# Altium schematic sheet ANNA-B5 Dice_Top.SchDoc("ANNA-B5 Dice_Top")
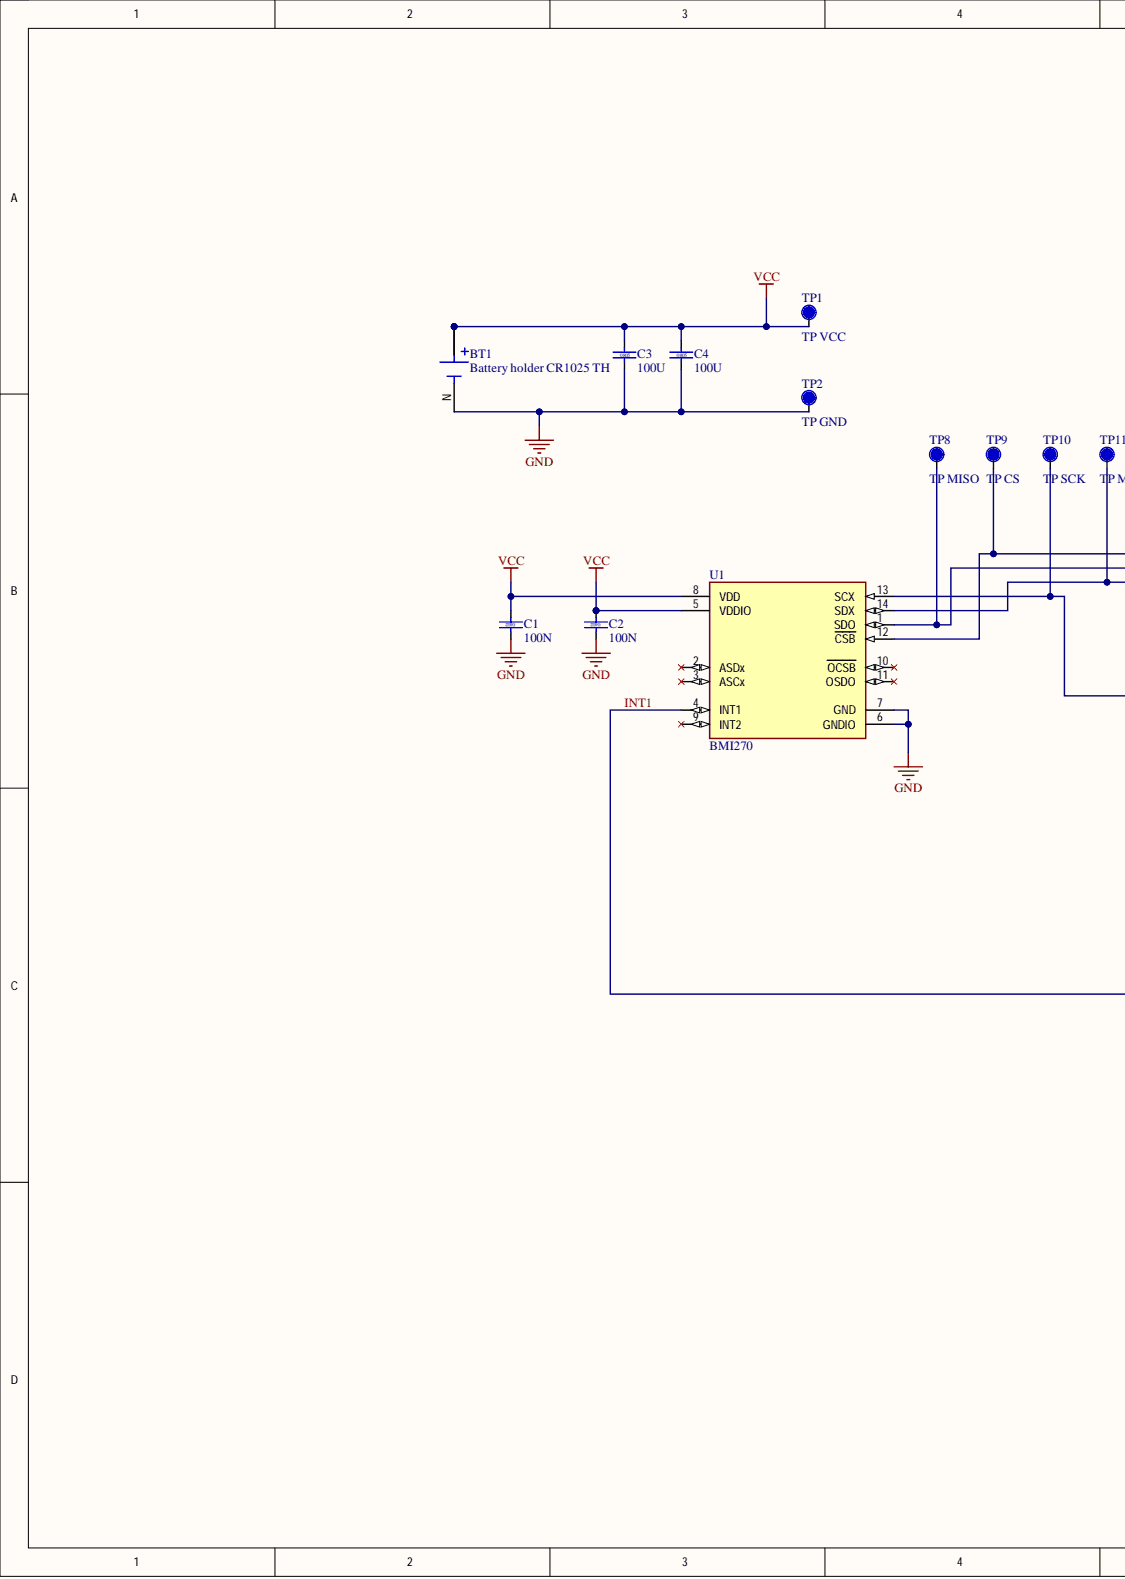
[diagram p0: ANNA-B5 Dice_Top.SchDoc, left]
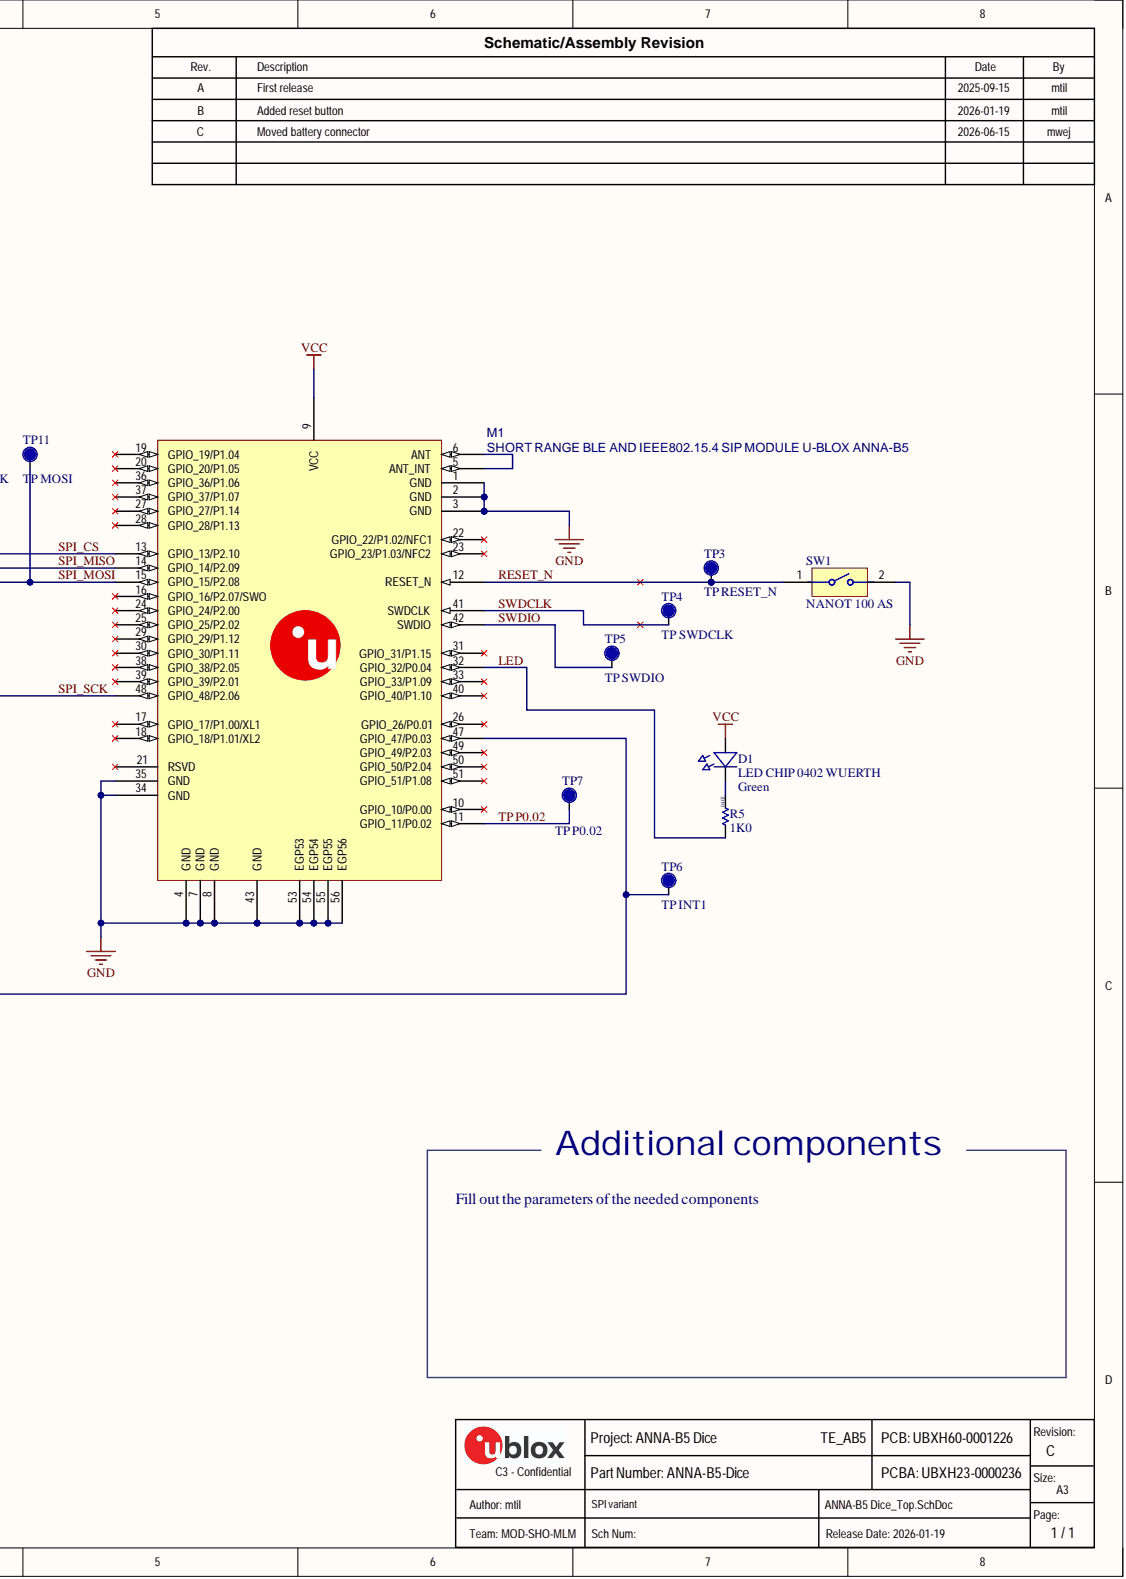
[diagram p1: ANNA-B5 Dice_Top.SchDoc, right]

## Parts
BT1  Battery holder CR1025 TH  value CR1025  [BAT_3031]
C1  value 100N  Case/Package: 0402  [Cap_0402_1100Lx600Wx600H_600x600Pad_350G_FLX]  (hidden comment: CAP CER X7R 0402 100N 10% 16V)
C2  value 100N  Case/Package: 0402  [Cap_0402_1100Lx600Wx600H_600x600Pad_350G_FLX]  (hidden comment: CAP CER X7R 0402 100N 10% 16V)
C3  value 100U  Case/Package: 0805  [CAP_0805_2200Lx1450Wx1400H_700x1500Pad_1100G_FLX]  (hidden comment: CAP CER X5R 0805 100U 20% 6.3V)
C4  value 100U  Case/Package: 0805  [CAP_0805_2200Lx1450Wx1400H_700x1500Pad_1100G_FLX]  (hidden comment: CAP CER X5R 0805 100U 20% 6.3V)
D1  LED CHIP 0402 WUERTH  Case/Package: 0402  Color: Green  [LED_0402]
M1  SHORT RANGE BLE AND IEEE802.15.4 SIP MODULE U-BLOX ANNA-B5  [ANNA-B5]
R5  value 1K0  Case/Package: 0402  [RES_0402_1100Lx600Wx400H_500x600Pad_400G_FLX]  (hidden comment: RES THICK FILM CHIP 0402 1K0 5% 100mW)
SW1  NANOT 100 AS  [NANOT160AS]
TP1  TP VCC  [TP10R_NO_SILK]
TP2  TP GND  [TP10R_NO_SILK]
TP3  TP RESET_N  [TP10R_NO_SILK]
TP4  TP SWDCLK  [TP10R_NO_SILK]
TP5  TP SWDIO  [TP10R_NO_SILK]
TP6  TP INT1  [TP10R_NO_SILK]
TP7  TP P0.02  [STP_600]
TP8  TP MISO  [STP_600]
TP9  TP CS  [STP_600]
TP10  TP SCK  [STP_600]
TP11  TP MOSI  [STP_600]
U1  BMI270  [LGA0.5P3x2.5_14Pin]

## Nets
GND: BT1.N C1.1 C2.1 C3.2 C4.2 M1.1(GND) M1.2(GND) M1.3(GND) M1.4(GND) M1.7(GND) M1.8(GND) M1.34(GND) M1.35(GND) M1.43(GND) M1.53(EGP53) M1.54(EGP54) M1.55(EGP55) M1.56(EGP56) SW1.2 TP2.1 U1.6(GNDIO) U1.7(GND)
VCC: BT1.P1 BT1.P2 C1.2 C2.2 C3.1 C4.1 D1.2 M1.9(VCC) TP1.1 U1.5(VDDIO) U1.8(VDD)
INT1: M1.47(GPIO_47/P0.03) TP6.1 U1.4(INT1)
LED: M1.32(GPIO_32/P0.04) R5.1
RESET_N: M1.12(RESET_N) SW1.1 TP3.1
SPI_CS: M1.13(GPIO_13/P2.10) TP9.1 U1.12(CSB)
SPI_MISO: M1.14(GPIO_14/P2.09) TP8.1 U1.1(SDO)
SPI_MOSI: M1.15(GPIO_15/P2.08) TP11.1 U1.14(SDX)
SPI_SCK: M1.48(GPIO_48/P2.06) TP10.1 U1.13(SCX)
SWDCLK: M1.41(SWDCLK) TP4.1
SWDIO: M1.42(SWDIO) TP5.1
TP P0.02: M1.11(GPIO_11/P0.02) TP7.1
NetD1_1: D1.1 R5.2
NetM1_5: M1.5(ANT_INT) M1.6(ANT)

Not connected: M1 10(GPIO_10/P0.00) 16(GPIO_16/P2.07/SWO) 17(GPIO_17/P1.00/XL1) 18(GPIO_18/P1.01/XL2) 19(GPIO_19/P1.04) 20(GPIO_20/P1.05) 21(RSVD) 22(GPIO_22/P1.02/NFC1) 23(GPIO_23/P1.03/NFC2) 24(GPIO_24/P2.00) 25(GPIO_25/P2.02) 26(GPIO_26/P0.01) 27(GPIO_27/P1.14) 28(GPIO_28/P1.13) 29(GPIO_29/P1.12) 30(GPIO_30/P1.11) 31(GPIO_31/P1.15) 33(GPIO_33/P1.09) 36(GPIO_36/P1.06) 37(GPIO_37/P1.07) 38(GPIO_38/P2.05) 39(GPIO_39/P2.01) 40(GPIO_40/P1.10) 49(GPIO_49/P2.03) 50(GPIO_50/P2.04) 51(GPIO_51/P1.08); U1 2(ASDx) 3(ASCx) 9(INT2) 10(OCSB) 11(OSDO)

## Library data of the parts
BT1 = UBXCMP-BAT-00022-8: Battery Clip; THM; 9-10mm Cell; PhosBronze/Tin-Nickel; Critical Part: No; Part Number: UBXH03-0000012; Component Mounting Type: Through Hole; Environmental Grade: RoHS; PLM Lifecycle Phase: Limited Production; PLM Revision: A; Use in new designs: Yes; Automotive: No; Check_prices: https://www.snapeda.com/parts/3031/Keystone/view-part/?ref=eda; Component Kind: Standard; Component Type: Standard; Design Item ID: 3031; Diameter: 10 mm; Height: 3 mm; Package: None; Part Status: Preferred; Pins: 3; Value: CR1025; Library Name: u-blox
C1 C2 = UBXCMP-CAP-00170-4: Critical Part: No; Part Number: UBXH01-0000233; Component Mounting Type: SMT; Environmental Grade: RoHS; JEDEC Type: S0402_C; Owner: Philippe Largier (null); Pack Type: DEFAULT; PLM Lifecycle Phase: Full Production; PLM Revision: A; Use in new designs: Yes; Automotive: No; Component Kind: Standard; Description: CAP CER X7R 0402 100N 10% 16V; Max Operating Temperature: 125°C; Maximum Voltage: 16V; Min Operating Temperature: -55°C; Part: CAP; Part Status: Preferred; Temperature Coefficient: X7R; Tolerance: 10%; Volume Use: Yes; Library Name: u-blox
C3 C4 = UBXCMP-CAP-00261-4: Critical Part: No; Part Number: UBXH01-0000327; Component Mounting Type: SMT; Environmental Grade: RoHS; JEDEC Type: S0805_C; Owner: Martin Grasse (null); Pack Type: DEFAULT; PLM Lifecycle Phase: Limited Production; PLM Revision: A; Use in new designs: Yes; Automotive: No; Component Kind: Standard; Description: CAP CER X5R 0805 100U 20% 6.3V; Max Operating Temperature: 85°C; Maximum Voltage: 6.3V; Min Operating Temperature: -55°C; Part: CAP; Part Status: Preferred; Temperature Coefficient: X5R; Tolerance: 20%; Volume Use: No; Library Name: u-blox
D1 = UBXCMP-LED-00019-3: Critical Part: No; Part: DIO_LED; Part Number: UBXH11-0000042; Component Mounting Type: SMT; Environmental Grade: RoHS; JEDEC Type: SWURTH_150040VS73; Number of Circuits: 1; Owner: George Metaxas (null); Pack Type: DEFAULT; PLM Lifecycle Phase: Full Production; PLM Revision: A; Use in new designs: Yes; Automotive: No; Component Kind: Standard; Description: LED CHIP 0402 WUERTH; Max Operating Temperature: 85°C; Min Operating Temperature: -40°C; Part Status: Preferred; Volume Use: Yes; Library Name: u-blox
M1 = UBXCMP-MOD-00552-4: Critical Part: No; Part: ublox_anna_b5; Part Number: UBXH29-0000036; Component Mounting Type: SMT; Core Architecture: Cortex®-M33; Environmental Grade: RoHS; Flash Size: 1524 KB; Internal Antenna: Yes; Owner: Yaqin Chen (null); Pack Type: Default; PLM Lifecycle Phase: Unreleased; PLM Revision: 1; RAM Size: 256 KB; RF Frequency: 2.4GHz; RF Protocol: BLE 6.0 and IEEE802.15.4-2020; Automotive: No; Component Kind: Standard; Description: SHORT RANGE BLE AND IEEE802.15.4 SIP MODULE U-BLOX ANNA-B5; Library Name: u-blox; Max Operating Temperature: 85°C; Min Operating Temperature: -40°C; Part Status: Preferred; Use in new designs: Yes; Volume Use: Yes
R5 = UBXCMP-RES-00738-3: Critical Part: No; Part Number: UBXH18-0008049; Component Mounting Type: SMT; Environmental Grade: RoHS; JEDEC Type: S0402_R; Owner: Roland Rust (null); Pack Type: DEFAULT; PLM Lifecycle Phase: Full Production; PLM Revision: A; Use in new designs: Yes; Automotive: No; Component Kind: Standard; Description: RES THICK FILM CHIP 0402 1K0 5% 100mW; Maximum Power: 100mW; Part: RES; Part Status: Preferred; Tolerance: 5%; Volume Use: Yes; Library Name: u-blox
SW1 = UBXCMP-SW-00073-7: Switch Tactile N.O. SPST Round Button Gull Wing 0.02A 15VDC 1N SMD T/R; Critical Part: No; Part Number: UBXH19-0000073; Component Mounting Type: SMT; Environmental Grade: RoHS; Maximum Current: 20mA; Owner: Magnus Tillgren (null); Pack Type: Default; PLM Lifecycle Phase: Unreleased; Switch-Button Circuit: SPST NO; Switch-Button Function: Off-MOM On; Use in new designs: Yes; Automotive: No; Component Kind: Standard; Height: 0.55mm; Length: 2.1mm; Max Operating Temperature: 85°C; Min Operating Temperature: -40°C; Part Status: Preferred; Pins: 4; Single Source: No; Volume Use: Yes; Width: 1.7mm; Library Name: u-blox
TP1-TP6 = UBXCMP-CU-00036-4: TESTPOINT 1MM CIRCULAR; Critical Part: No; Part Number: UBXH00-0000096; Component Mounting Type: SMT; Owner: Eric Moog (null); PLM Lifecycle Phase: Unreleased; Use in new designs: Yes; Automotive: No; Component Kind: Standard; Environmental Grade: N/A; Max Operating Temperature: 125°C; Min Operating Temperature: -55°C; Part Status: Preferred; Volume Use: Yes; Library Name: u-blox
TP7-TP11 = UBXCMP-CU-00047-4: Test point Circular 0.6mm w/o Silkscreen; Critical Part: No; Part Number: UBXH00-0000114; Component Mounting Type: SMT; Owner: Osman Yazici (null); Pack Type: Default; PLM Lifecycle Phase: Unreleased; Use in new designs: Yes; Automotive: No; Component Kind: Standard; Environmental Grade: N/A; Part Status: Preferred; Volume Use: No; Library Name: u-blox
U1 = UBXCMP-SENS-00078-7: IMU ACCEL/GYRO I2C/SPI 14LGA; Critical Part: No; Part Number: UBXH45-0000008; Body Size: 3 x 2.5mm; Component Mounting Type: SMT; Controller Interface: SPI; Environmental Grade: RoHS; Max Supply Voltage: 3.6V; Min Supply Voltage: 1.71V; Pack Type: Default; PLM Lifecycle Phase: Full Production; PLM Revision: A; Sensor Range Max: 16g; Sensor Type: Motion; Use in new designs: Yes; Automotive: No; Case/Package: LGA14; Component Kind: Standard; Height: 0.83mm; Max Operating Temperature: 85°C; Min Operating Temperature: -40°C; Part Status: Preferred; Pins: 14; Library Name: u-blox
